# Altium schematic sheet MAIN.SchDoc
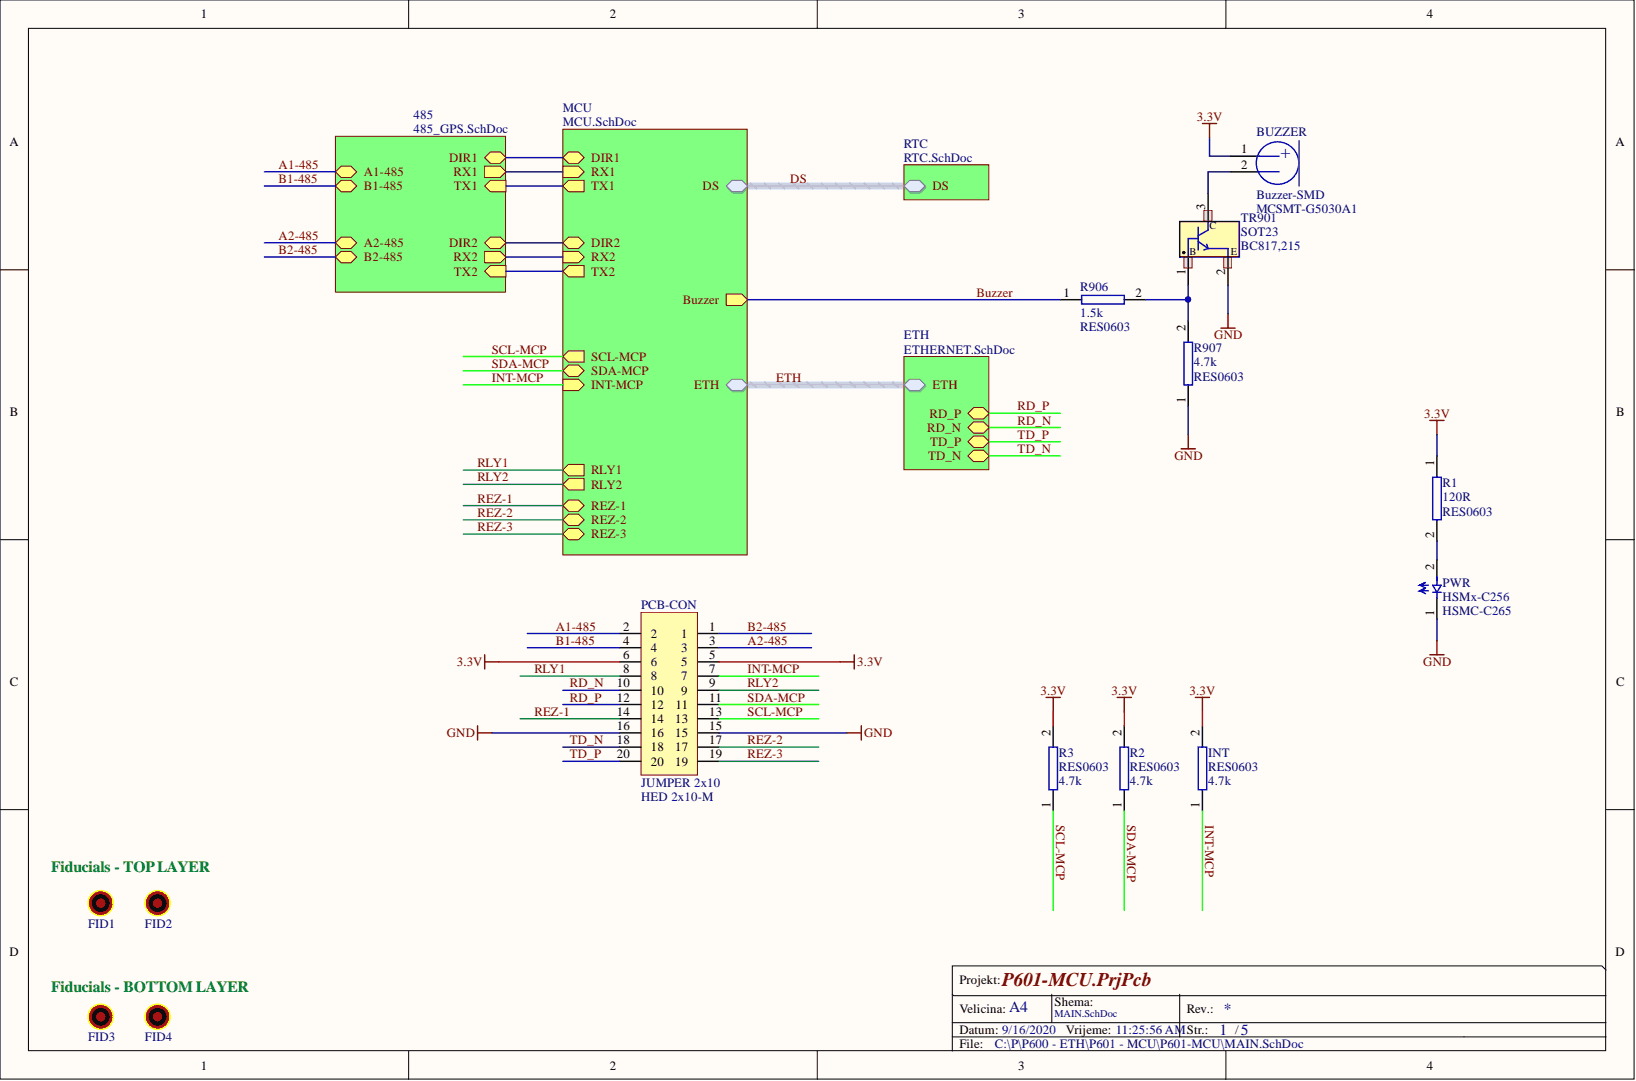
[diagram p0: MAIN.SchDoc]

## Parts
BUZZER  MCSMT-G5030A1  [Buzzer-SMD]
FID1  FID  [FUD]  (no BOM)
FID2  FID  [FUD]  (no BOM)
FID3  FID  [FUD]  (no BOM)
FID4  FID  [FUD]  (no BOM)
INT  4.7k  [res0603]
PCB-CON  JUMPER 2x10  Package: HED 2x10-M  [Jumper 2x10 - SIDE]
PWR  HSMC-C265  [HSMx-C256]
R1  120R  [res0603]
R2  4.7k  [res0603]
R3  4.7k  [res0603]
R906  1.5k  [res0603]
R907  4.7k  [res0603]
TR901  BC817,215  [SOT23]

## Nets
3.3V: BUZZER.1 INT.2 PCB-CON.5 PCB-CON.6 R1.1 R2.2 R3.2
GND: PCB-CON.15 PCB-CON.16 PWR.1 R907.1 TR901.2
A1-485: PCB-CON.2
A2-485: PCB-CON.3
B1-485: PCB-CON.4
B2-485: PCB-CON.1
BUZZER: R906.1
INT-MCP: INT.1 PCB-CON.7
RD_N: PCB-CON.10
RD_P: PCB-CON.12
REZ-1: PCB-CON.14
REZ-2: PCB-CON.17
REZ-3: PCB-CON.19
RLY1: PCB-CON.8
RLY2: PCB-CON.9
SCL-MCP: PCB-CON.13 R3.1
SDA-MCP: PCB-CON.11 R2.1
TD_N: PCB-CON.18
TD_P: PCB-CON.20
NetBUZZER_2: BUZZER.2 TR901.3
NetPWR_2: PWR.2 R1.2
NetR906_2: R906.2 R907.2 TR901.1
DIR1:
DIR2:
DS.32KHZ:
DS.INT:
DS.SCL:
DS.SDA:
ETH.ECRS_DV:
ETH.EINT:
ETH.EMDC:
ETH.EMDIO:
ETH.EREF_CLK:
ETH.ERXD0:
ETH.ERXD1:
ETH.ERXERR:
ETH.ETXD0:
ETH.ETXD1:
ETH.ETXEN:
RX1:
RX2:
TX1:
TX2:

## Library data of the parts
BUZZER = B_MCSMT-G5030A1: MCSMT-G5030A1-3712 - MAGNETIC BUZZER & TRANSDUCER, 4KHZ, 78DB; Dobavljač: Farnell; Location: OR5A46; Šifra: 2396072; Component Kind: Standard; Library Name: ITR000.SchLib; Package: Buzzer-SMD; Supplier 1: Farnell; Supplier 2: TME; Supplier Part Number 1: 2396072; Supplier Part Number 2: LD-BZEL-T54-0505; Value: MCSMT-G5030A1
FID1-FID4 = FIDUCIAL_POINT: Description: FIDUCIAL_POINT; Library Name: ITR000.SchLib; Package: FIDUCIAL; Value: FID
INT R2 R3 R907 = RES_4.7k_0603: RES  4.7k 0603; Dobavljač: Farnell; Location: OR2E46; Šifra: 2059619; Component Kind: Standard; DataSheet: http://www.vishay.com/docs/20035/dcrcwe3.pdf; LCSC Part #: C23162; Library Name: ITR000.SchLib; Package: RES0603; Supplier 1: Farnell; Supplier 2: TME; Supplier 3: JLC PCB; Supplier Part Number 1: 2059619; Supplier Part Number 2: SMD0603-4K7-1%; Supplier Part Number 3: 0603WAF4701T5E; Value: 4.7k
PCB-CON = J_2x10_PIN_MALE: BOARD-BOARD CONN, HEADER, 16WAY, 2ROW; Dobavljač: TME; Šifra: ASS02029Z; Component Kind: Standard; Library Name: ITR000.SchLib; Supplier 2: TME; Supplier 3: JLC PCB; Supplier Part Number 2: ASS02029Z; Value: JUMPER 2x10
PWR = LED_HSMCC265: LED diode bottom mounted; Dobavljač: Farnell; Location: OR3B13; Šifra: 1652083; Component Kind: Standard; DataSheet: http://www.farnell.com/datasheets/87289.pdf; Library Name: ITR000.SchLib; Package: HSMx-C256; Supplier 1: Farnell; Supplier 2: TME; Supplier Part Number 1: 1652083; Supplier Part Number 2: HSMG-C265; Value: HSMC-C265
R1 = RES_120R_0603: RES 120R 0603; Dobavljač: Farnell; Location: OR2E46; Šifra: 2059577; Component Kind: Standard; DataSheet: http://www.vishay.com/docs/20035/dcrcwe3.pdf; LCSC Part #: C22787; Library Name: ITR000.SchLib; Package: RES0603; Supplier 1: Farnell; Supplier 2: TME; Supplier 3: JLC PCB; Supplier Part Number 1: 2059577; Supplier Part Number 2: RC0603JR-07120R; Supplier Part Number 3: 0603WAF1200T5E; Value: 120R
R906 = RES_1.5k_0603: RES  1.5k 0603; Dobavljač: Farnell; Location: OR2G13; Šifra: 9238506; Component Kind: Standard; LCSC Part #: C22843; Library Name: ITR000.SchLib; Package: RES0603; Supplier 1: Farnell; Supplier 2: TME; Supplier 3: JLC PCB; Supplier Part Number 1: 9238506; Supplier Part Number 2: SMD0603-1K5-1%; Supplier Part Number 3: 0603WAF1501T5E; Value: 1.5k
TR901 = TR_BC817: NXP  BC817  TRANSISTOR, NPN, SOT-23, 45V; Dobavljač: Farnell; Location: OR3E13; Šifra: 1081223; Component Kind: Standard; DataSheet: http://www.nxp.com/documents/data_sheet/BC817_BC817W_BC337.pdf; LCSC Part #: C39828; Library Name: ITR000.SchLib; Package: SOT23; Simulation: QBC817; Supplier 1: Farnell; Supplier 2: TME; Supplier 3: JLC PCB; Supplier Part Number 1: 1081223; Supplier Part Number 2: BC817-25.215; Supplier Part Number 3: BC817-25,215; Value: BC817,215
